# Altium schematic sheet Master.SchDoc
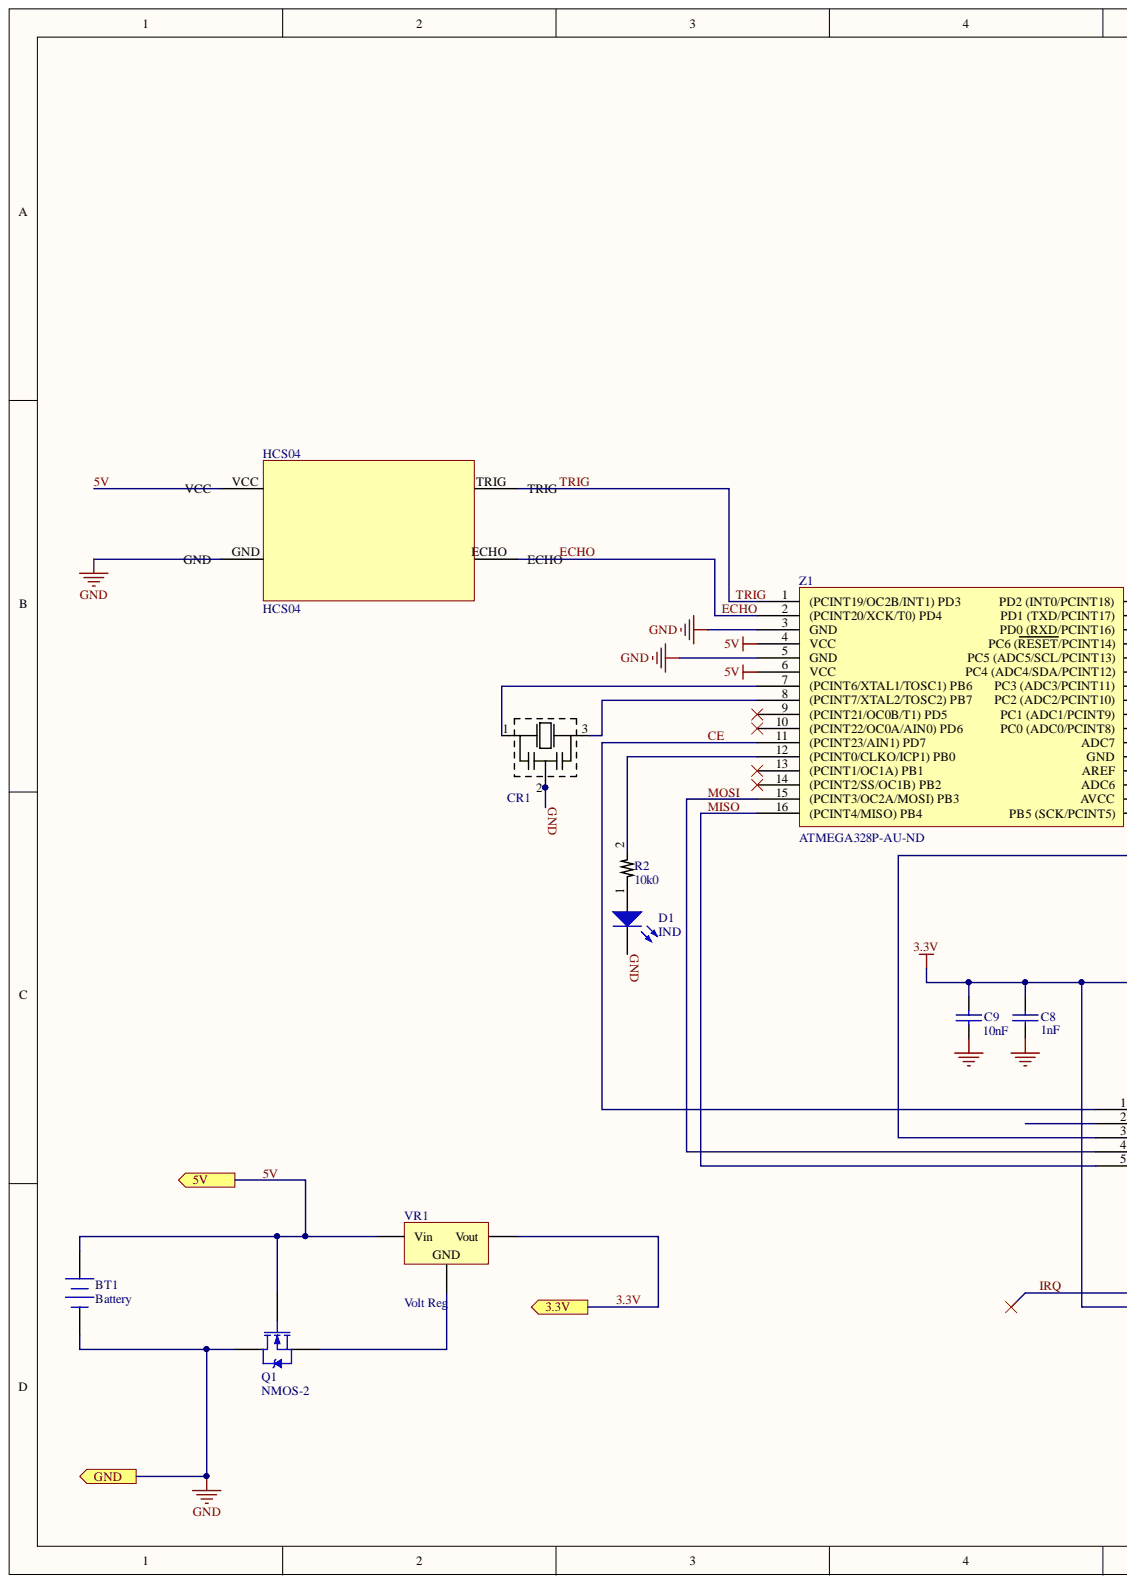
[diagram p0: Master.SchDoc, left]
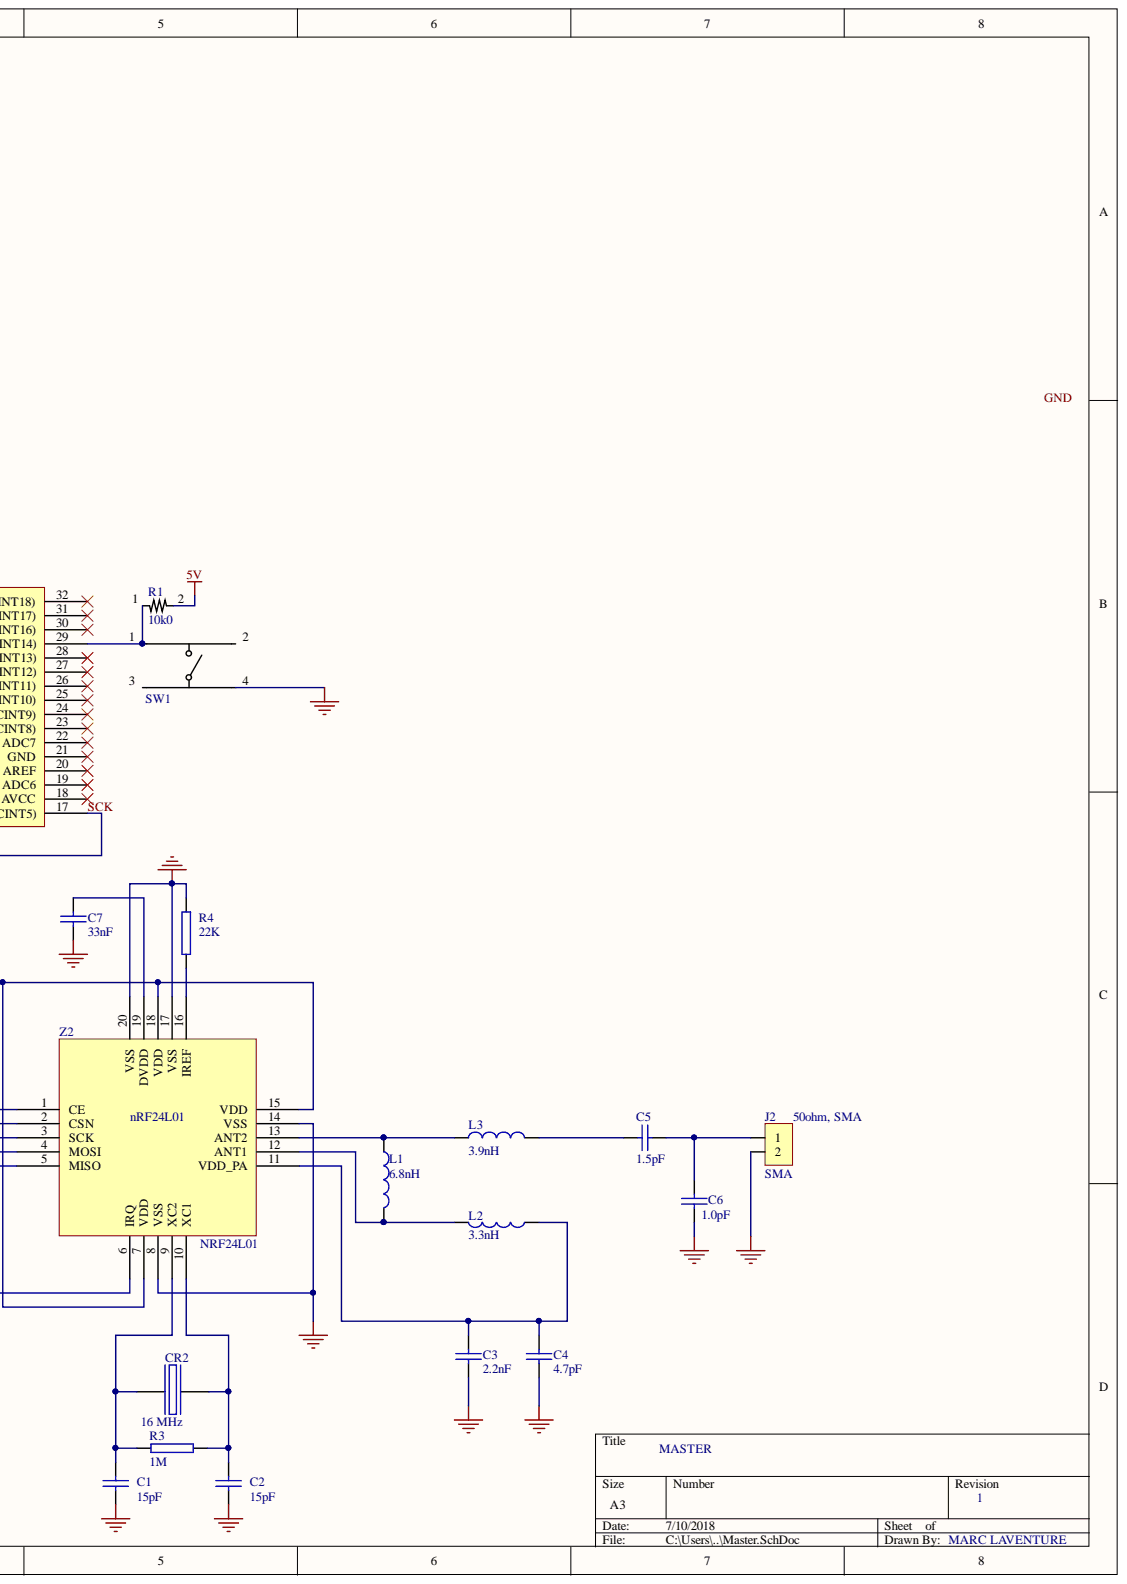
[diagram p1: Master.SchDoc, right]

## Parts
BT1  Battery  [BAT-2]
C1  15pF  [0402s]
C2  15pF  [0402s]
C3  2.2nF  [0402s]
C4  4.7pF  [0402s]
C5  1.5pF  [0402s]
C6  1.0pF  [0402s]
C7  33nF  [0402s]
C8  1nF  [0402s]
C9  10nF  [0402s]
CR1  CSTCE16M0V53-R0  [CSTCE16M0V53-R0]  (value not drawn)
CR2  16 MHz  [BT-XTAL]
D1  IND  [3.2X1.6X1.1]
HCS04  HCS04
J2  SMA  [SMA_H]
L1  6.8nH  [0402s]
L2  3.3nH  [0402s]
L3  3.9nH  [0402s]
Q1  NMOS-2  [TO-220AB]
R1  10k0  [R0603]
R2  10k0  [R0603]
R3  1M  [0402s]
R4  22K  [0402s]
SW1  PTS525SM15SMTR2  [PTS525SM15SMTR2]  (value not drawn)
VR1  Volt Reg  [D2PAK_N]
Z1  ATMEGA328P  Supplier Part Number 1: ATMEGA328P-AU-ND  [ATMEGA328P-15AZ - 2]
Z2  NRF24L01  [QFN20L/5x5]

## Ports
3.3V, 5V, GND

## Nets
VSS: CR2.2 CR2.4
3.3V: C8.1 C9.1 VR1.3 Z2.7(VDD) Z2.15(VDD) Z2.18(VDD) ; port 3.3V
5V: BT1.1 Q1.1 R1.2 VR1.1 Z1.4(VCC) Z1.6(VCC) ; port 5V
CE: Z1.11((PCINT23/AIN1)_PD7) Z2.1(CE)
ECHO: Z1.2((PCINT20/XCK/T0)_PD4)
GND: BT1.2 C1.2 C2.1 C3.1 C4.1 C6.2 C7.2 C8.2 C9.2 CR1.2 D1.2 J2.2 Q1.2 R4.2 SW1.4 Z1.3(GND) Z1.5(GND) Z2.8(VSS) Z2.14(VSS) Z2.17(VSS) Z2.20(VSS) ; port GND
IRQ: Z2.6(IRQ)
MISO: Z1.16((PCINT4/MISO)_PB4) Z2.5(MISO)
MOSI: Z1.15((PCINT3/OC2A/MOSI)_PB3) Z2.4(MOSI)
SCK: Z1.17(PB5_(SCK/PCINT5)) Z2.3(SCK)
TRIG: Z1.1((PCINT19/OC2B/INT1)_PD3)
NetC1_1: C1.1 CR2.1 R3.1 Z2.9(XC2)
NetC2_2: C2.2 CR2.3 R3.2 Z2.10(XC1)
NetC3_2: C3.2 C4.2 L2.1 Z2.11(VDD_PA)
NetC5_1: C5.1 C6.1 J2.1
NetC5_2: C5.2 L3.2
NetC7_1: C7.1 Z2.19(DVDD)
NetCR1_1: CR1.1 Z1.7((PCINT6/XTAL1/TOSC1)_PB6)
NetCR1_3: CR1.3 Z1.8((PCINT7/XTAL2/TOSC2)_PB7)
NetD1_1: D1.1 R2.1
NetL1_1: L1.1 L3.1 Z2.13(ANT2)
NetL1_2: L1.2 L2.2 Z2.12(ANT1)
NetQ1_3: Q1.3 VR1.2
NetR1_1: R1.1 SW1.1 Z1.29(PC6_(RESET/PCINT14))
NetR2_2: R2.2 Z1.12((PCINT0/CLKO/ICP1)_PB0)
NetR4_1: R4.1 Z2.16(IREF)

Not connected: HCS04 ECHO GND TRIG VCC; SW1 2 3; Z1 9((PCINT21/OC0B/T1)_PD5) 10((PCINT22/OC0A/AIN0)_PD6) 13((PCINT1/OC1A)_PB1) 14((PCINT2/SS/OC1B)_PB2) 18(AVCC) 19(ADC6) 20(AREF) 21(GND) 22(ADC7) 23(PC0_(ADC0/PCINT8)) 24(PC1_(ADC1/PCINT9)) 25(PC2_(ADC2/PCINT10)) 26(PC3_(ADC3/PCINT11)) 27(PC4_(ADC4/SDA/PCINT12)) 28(PC5_(ADC5/SCL/PCINT13)) 30(PD0_(RXD/PCINT16)) 31(PD1_(TXD/PCINT17)) 32(PD2_(INT0/PCINT18)); Z2 2(CSN)

## Library data of the parts
BT1 = Battery: Multicell Battery; Component Kind: Standard; LatestRevisionDate: 17-Jul-2002; LatestRevisionNote: Re-released for DXP Platform.; Library Name: Miscellaneous Devices.IntLib; PackageDescription: Battery; 2 Leads; PackageReference: BAT-2; Published: 24-Mar-1999; Publisher: Altium Limited
C1-C9 = CAP: Part Field 1: 0402; Component Kind: Standard
CR2 = BT-XTAL: Part Field 1: 0603; Component Kind: Standard
D1 = LED2: Typical RED, GREEN, YELLOW, AMBER GaAs LED; Component Kind: Standard; LatestRevisionDate: 17-Jul-2002; LatestRevisionNote: Re-released for DXP Platform.; Library Name: Miscellaneous Devices.IntLib; PackageDescription: SM LED; 2 Flat Leads; PackageReference: 3.2X1.6X1.1; PackageVersion: Feb-2002; Published: 8-Jun-2000; Simulation: LED2; Supplier 1: Digi-Key; Supplier Part Number 1: 160-1456-1-ND; Publisher: Altium Limited
J2 = CON2: Component Kind: Standard
L1-L3 = INDUCTOR1: Part Field 1: 0402; Component Kind: Standard
Q1 = NMOS-2: N-Channel Power MOSFET; Note: Pin 2 is electrically connected to pin 4.; Component Kind: Standard; ComponentLink1Description: Manufacturer Link; ComponentLink1URL: http://www.irf.com/; DatasheetVersion: PD-9.814; LatestRevisionDate: 17-Jul-2002; LatestRevisionNote: Re-released for DXP Platform.; Library Name: Miscellaneous Devices.IntLib; PackageDescription: TO, Thru-Hole, Vertical, Heatsink Mounted; 3 In-Line Leads; Pitch 2.54 mm; PackageReference: TO-220AB; PackageVersion: Feb-1997; Published: 8-Jun-2000; Simulation: IRF1010; Publisher: Altium Limited
R1 R2 = Resistor: 0603; Component Kind: Standard; Supplier 1: Digi-Key; Supplier 2: Digi-Key; Supplier Part Number 2: 311-10.0KHRCT-ND; Library Name: CANduino_gyro.SchLib
R3 R4 = RES2: Part Field 1: 0402; Component Kind: Standard
VR1 = Volt Reg: Voltage Regulator; Component Kind: Standard; LatestRevisionDate: 29-May-2009; LatestRevisionNote: IPC-7351 Footprint Added.; Library Name: Miscellaneous Devices.IntLib; PackageDescription: 3-Pin Transistor Outline Package 2.54 mm Pitch, 15.1 mm Lead Span; PackageReference: D2PAK; Published: 24-Mar-1999; Publisher: Altium Limited
Z1 = ATMEGA328P: TQFN; Component Kind: Standard; Supplier 1: Digi-Key; Library Name: CANduino_gyro.SchLib
Z2 = NRF24L01: Part Field 1: 0603; Component Kind: Standard
CR1 = CSTCE16M0V53-R0: Component Kind: Standard; Supplier 1: Digi-Key; Supplier Part Number 1: 490-1198-1-ND; Library Name: CANduino_gyro.SchLib
HCS04 = HCS04: Component Kind: Standard; Library Name: Schlib1.SchLib
SW1 = PTS525SM15SMTR2: Component Kind: Standard; Supplier 1: Digi-Key; Supplier Part Number 1: CKN9104CT-ND; Library Name: CANduino_gyro.SchLib
